# Altium schematic sheet PAGE2 - POWER, RTC, RPI.SchDoc (continued)
## Library data of the parts (continued)
U4 = MCP3021A5T-E/OT: MICROCHIP - MCP3021A5T-E/OT - 10BIT ADC, I2C, 1CH, SMD, SOT-23A-5; ADC / DAC Case Style: SOT-23; Automotive Qualification Standard: AEC-Q100; Base Number: 3021; Conversion Time: 45.4; Data Interface: I2; Input Channel Type: Single Ended; Linearity Error ADC / DAC +: 1; No. of Bits: 10; No. of Pins: 5; Product Range: Single 10; Sampling Rate: 22.3; Supply Current: 175; Supply Voltage Max: 5.5; Supply Voltage Min: 2.7; Supply Voltage Range: 2.7V to 5.5; Supply Voltage Type: Single; SVHC: No SVHC (17-Dec-2015); Voltage Regulator Type: ADC<!--FANDB--><div class="features-and-benefits-container"><div class="features-and-benefits-description"><span class="features-benefits-value">The MCP3021A5T-E/OT is a 10-bit low power Analogue-to-digital Converter (ADC). This device provides one/single-ended input with very low power consumption. The MCP3021 provides a low maximum conversion current and standby current of 250µA and 1µA respectively. Communication to the MCP3021 is performed using a 2; Component Kind: Standard; ComponentLink1Description: http://www.farnell.com/datasheets/2124926.pdf; ComponentLink1URL: http://www.farnell.com/datasheets/2124926.pdf; ComponentLink2Description: http://www.farnell.com/cad/1545509.zip; ComponentLink2URL: http://www.farnell.com/cad/1545509.zip; ComponentLink3Description: http://www.farnell.com/datasheets/2338016.pdf; ComponentLink3URL: http://www.farnell.com/datasheets/2338016.pdf; IC Case Style: SOT-23; Library Name: PiRA-RTC-HAT-SchLib.SchLib; Linearity Error ADC / DAC -: 1; Manufacturer: MICROCHIP; Manufacturer Part Number: MCP3021A5T-E/OT; MSL: MSL 1 - Unlimited; No. of Channels: 1; Operating Temperature Max: 125; Operating Temperature Min: -40; Operating Temperature Range: -40°C to +125; Packaging: Cut Tape; Pricing 1: 1=0.926, 10=0.855, 25=0.759, 50=0.716, 100=0.648 (GBP); Resolution (Bits): 10; RoHS: YES; Stock 1: 8474; Supplier 1: Farnell; Supplier Part Number 1: 1332097; Supply Voltage Range - Analogue: 2.7V to 5.5; Termination Type: Surface Mount Device
U5 U6 = DMG6602SVT: DIODES INC. - DMG6602SVT - MOSFET, NP CH, , 30V, 3.4, ATSOT23; Continuous Drain Current Id: 3.4; Continuous Drain Current Id, P Channel: -2.8; Drain Source Voltage Vds: 30; Drain Source Voltage Vds, P Channel: -30; Module Configuration: Dual; No. of Pins: 6; On Resistance Rds(on): 0.038; On Resistance Rds(on), P Channel: 0.073; Power Dissipation Pd: 1.12; Rds(on) Test Voltage Vgs: 10; SVHC: No SVHC (17-Dec-2015); Threshold Voltage Vgs: 1; Transistor Case Style: TSOT-26; Transistor Polarity: N and P Channel; Automotive Qualification Standard: -; Component Kind: Standard; ComponentLink1Description: http://www.farnell.com/datasheets/1915929.pdf; ComponentLink1URL: http://www.farnell.com/datasheets/1915929.pdf; Continuous Drain Current Id, N Channel: 3.4; Drain Source Voltage Vds, N Channel: 30; Library Name: PiRA-RTC-HAT-SchLib.SchLib; Manufacturer: DIODES INC.; Manufacturer Part Number: DMG6602SVT; MSL: -; On Resistance Rds(on), N Channel: 0.038; Operating Temperature Max: 150; Operating Temperature Min: -55; Operating Temperature Range: -55°C to +150°C<!--FANDB--><div class="features-and-benefits-container"><div class="features-and-benefits-description"><span class="features-benefits-value">The DMG6602SVT from Diode Inc is a surface mount complementary pair enhancement mode MOSFET in TSOT-26 package. This MOSFET features low input capacitance, fast switching speed and low input/output leakage, designed to minimize the onstate resistance and maintain superior switching performance, making it ideal for high efficiency power management applications and backlighting.</span></div><div class="features-and-benefits-list"><ul class="features-and-benefits-list-list"><li class="features-and-benefits-list-item">Automotive grade AEC-Q101 qualified</li><li class="features-and-benefits-list-item">UL recognized</li><li class="features-and-benefits-list-item">Drain to source voltage (Vds) of 30V</li><li class="features-and-benefits-list-item">Gate to source voltage (Vgs) of ±20V</li><li class="features-and-benefits-list-item">Continuous drain current of 3.4A</li><li class="features-and-benefits-list-item">Power dissipation (Pd) of 1.27W</li><li class="features-and-benefits-list-item">Operating temperature range -55°C to 150°C</li><li class="features-and-benefits-list-item">Low on state resistance of 38mohm at Vgs of 10; Pricing 1: 1=0.363, 25=0.339, 100=0.169, 250=0.166, 500=0.108, 1000=0.0809, 2000=0.0793, 6000=0.0777 (GBP); Product Range: -; RoHS: YES; Stock 1: 4881; Supplier 1: Farnell; Supplier Part Number 1: 2061522
U7 = Lora RFM95 868M: RFM95 ULTRA-LONG RANGE TRANSCEIV; Manufacturer: Seeed Technology Co., Ltd; Manufacturer Part Number: 109990166; Current - Receiving: 12.1mA; Current - Transmitting: 120mA; Data Rate: 300kbps; Frequency: 868MHz; Modulation: FSK, GFSK, GMSK, MSK, OOK; Power - Output: 20dBm; Protocol: LoRa™; RF Family/Standard: General ISM < 1GHz; Sensitivity: -148dBm; Serial Interfaces: SPI; Voltage - Supply: 1.8 V ~ 3.7 V; Antenna Type: -; Category: RF/IF and RFID; Component Kind: Standard; ComponentLink1Description: https://github.com/SeeedDocument/RFM95-98_LoRa_Module/blob/master/RFM95_96_97_98_DataSheet.pdf; ComponentLink1URL: https://github.com/SeeedDocument/RFM95-98_LoRa_Module/blob/master/RFM95_96_97_98_DataSheet.pdf; Library Name: Lora_Schlib.SchLib; Memory Size: -; Mounting Type: Surface Mount; Operating Temperature: -20°C ~ 70°C; Package / Case: Module; Packaging: Bulk; Part Status: Active; Pricing 1: 1=7.09 (USD); RoHS: Not Applicable; Stock 1: 211; Supplier 1: Digi-Key; Supplier Part Number 1: 1597-1488-ND
U8 = TPL5110DDCT: TEXAS INSTRUMENTS - TPL5110DDCT - NANO TIMER, SINGLE, 5.5V, SOT-23-6; Digital IC Case: SOT-23; No. of Pins: 6; Supply Voltage Max: 5.5; Supply Voltage Min: 1.8; SVHC: No SVHC (15-Jun-2015)<!--FANDB--><div class="features-and-benefits-container"><div class="features-and-benefits-description"><span class="features-benefits-value">The TPL5110DDCT is an Ultralow Power Timer with MOS driver and MOSFET power ON ideal for power gating in duty cycled or battery powered applications. Consuming only 35nA, the TPL5110 can enable the power supply line and drastically reduce the overall system stand by current during the sleep time. Such power savings enable the use of significantly smaller batteries making it well suited for energy harvesting or wireless sensor applications. The TPL5110 provides selectable timing intervals from 100ms to 7200s and is designed for power gating applications. In addition, the TPL5110 has an unique one-shot feature where the timer will only power the MOSFET for one cycle.</span></div><div class="features-and-benefits-list"><ul class="features-and-benefits-list-list"><li class="features-and-benefits-list-item">35nA Typical current consumption at 2.5V</li><li class="features-and-benefits-list-item">100ms to 7200s Selectable time intervals</li><li class="features-and-benefits-list-item">1; Component Kind: Standard; ComponentLink1Description: http://www.ti.com/general/docs/lit/getliterature.tsp?genericPartNumber=TPL5110&amp;&amp;fileType=pdf; ComponentLink1URL: http://www.ti.com/general/docs/lit/getliterature.tsp?genericPartNumber=TPL5110&amp;&amp;fileType=pdf; ComponentLink2Description: http://www.farnell.com/datasheets/2037314.pdf; ComponentLink2URL: http://www.farnell.com/datasheets/2037314.pdf; Frequency: -; Library Name: PiRA-RTC-HAT-SchLib.SchLib; Manufacturer: TEXAS INSTRUMENTS; Manufacturer Part Number: TPL5110DDCT; MSL: MSL 1 - Unlimited; Operating Temperature Max: 105; Operating Temperature Min: -40; Packaging: Each; Pricing 1: 1=1, 10=0.703, 100=0.569, 250=0.528, 500=0.474, 1000=0.325 (GBP); Product Range: -; RoHS: YES; Stock 1: 1212; Supplier 1: Farnell; Supplier Part Number 1: 2471145
J1 = Socket: Description: Socket; LatestRevisionDate: 17-Jul-2002; LatestRevisionNote: Re-released for DXP Platform.; Library Name: Miscellaneous Connectors.IntLib; Signal Integrity: Connector; Publisher: Altium Limited
J2-J4 = solder_jumper: Library Name: PiRA-RTC-HAT-SchLib.SchLib
